# Altium schematic sheet IO.SchDoc(IO)
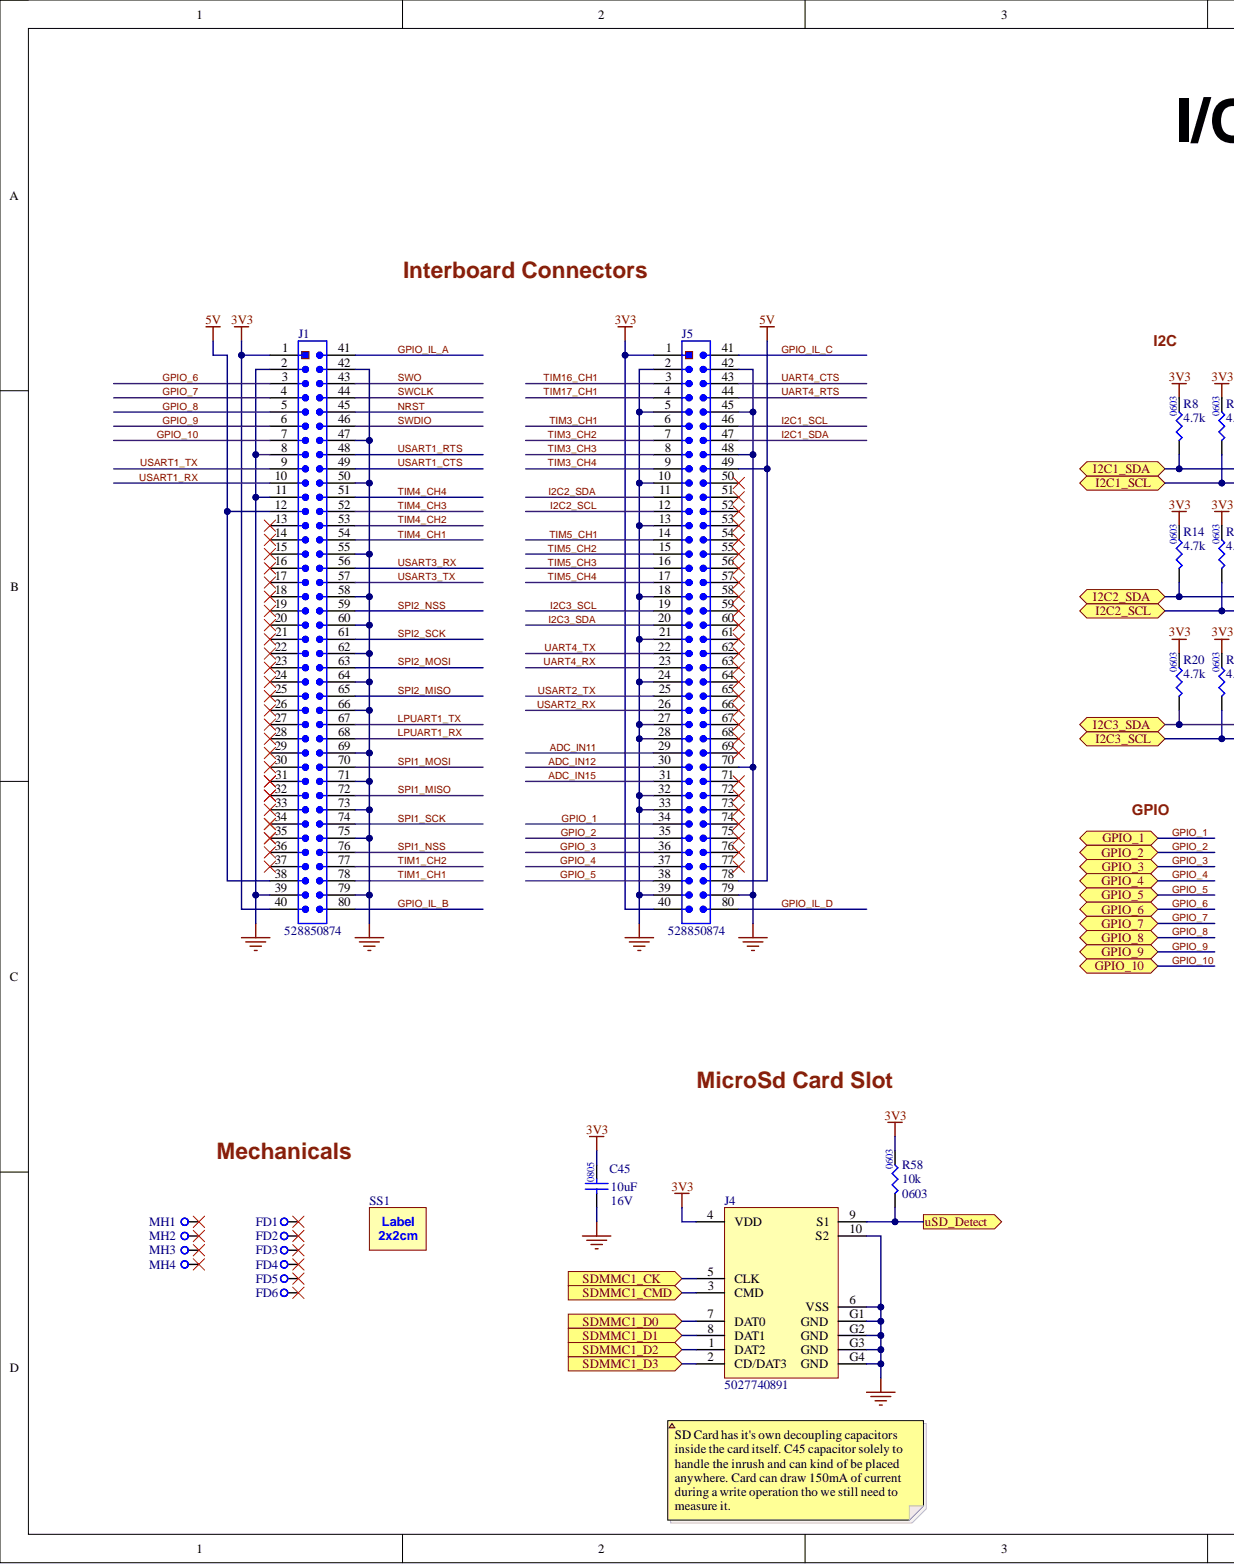
[diagram p0: IO.SchDoc, left]
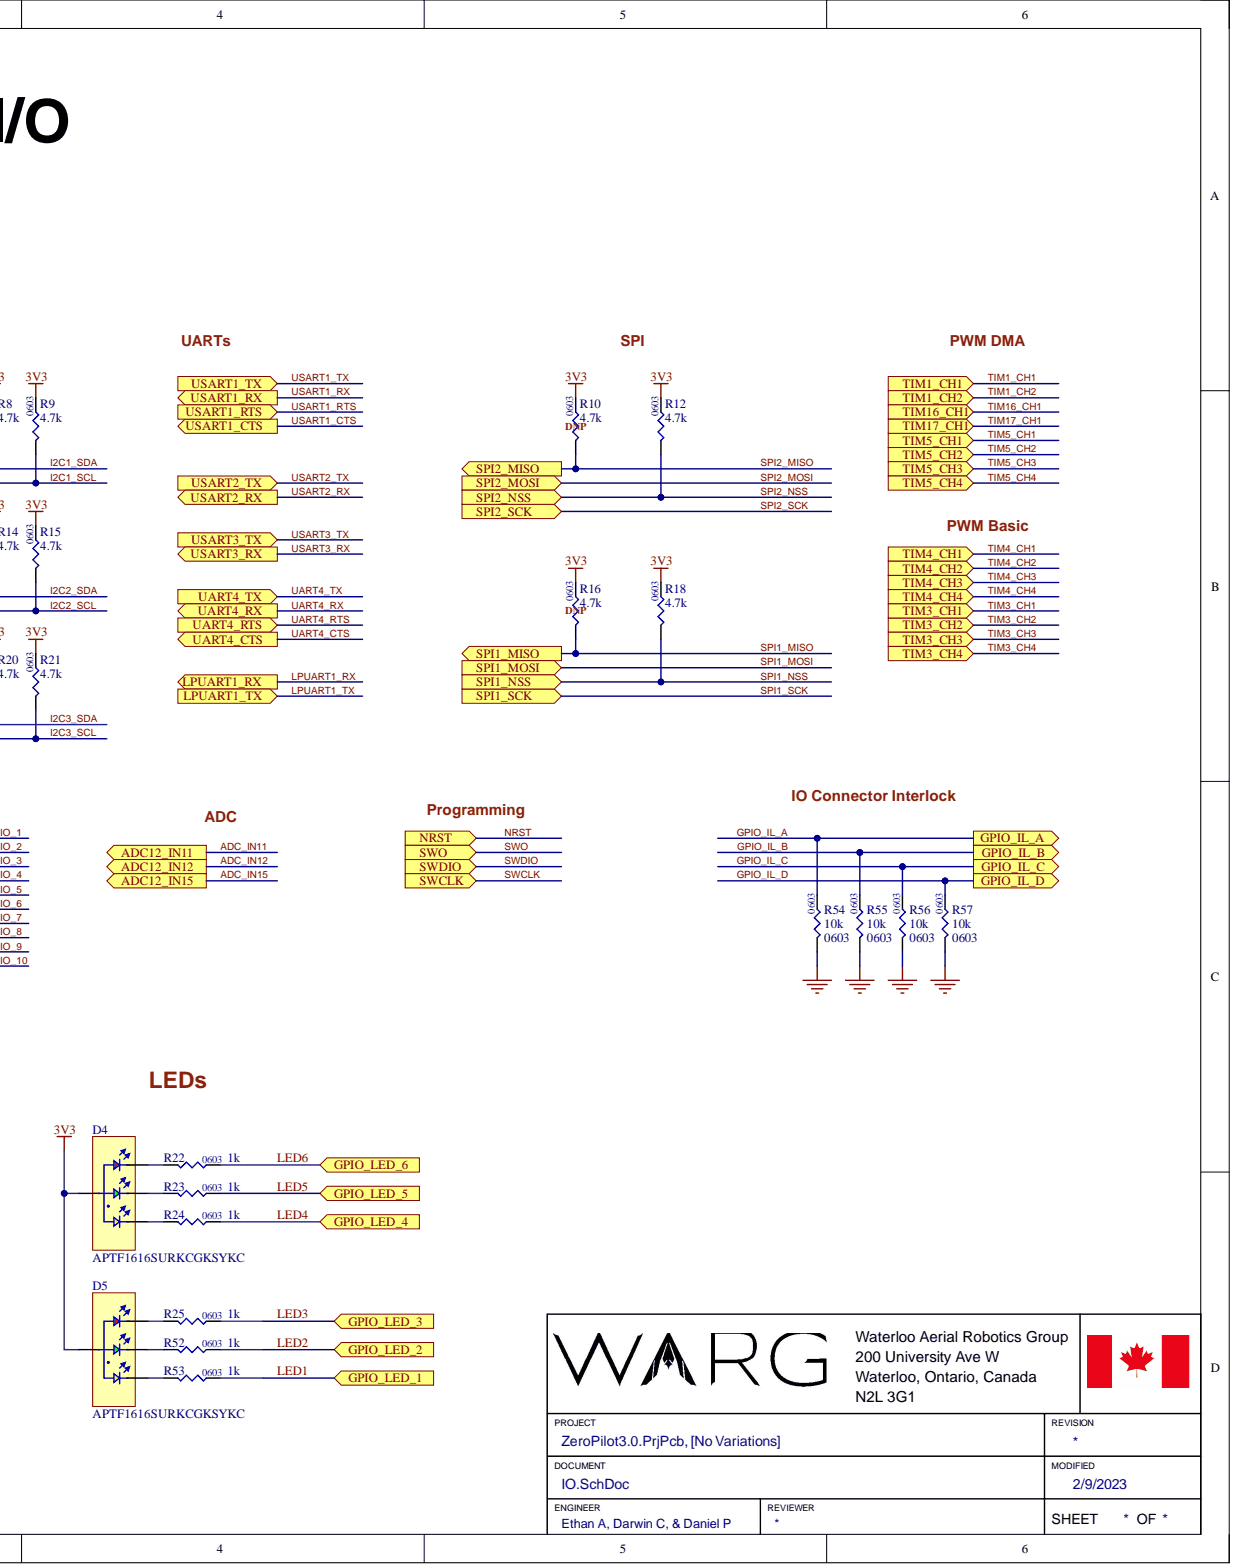
[diagram p1: IO.SchDoc, right]

## Parts
C45  value 10uF  Capacitance: 10uF  Package: 0805  Voltage: 16V  (hidden comment: CL21A106KOQNNNE)
D4  APTF1616SURKCGKSYKC
D5  APTF1616SURKCGKSYKC
FD1  FIDUCIAL-STANDARD  (value not drawn)
FD2  FIDUCIAL-STANDARD  (value not drawn)
FD3  FIDUCIAL-STANDARD  (value not drawn)
FD4  FIDUCIAL-STANDARD  (value not drawn)
FD5  FIDUCIAL-STANDARD  (value not drawn)
FD6  FIDUCIAL-STANDARD  (value not drawn)
J1  528850874
J4  5027740891
J5  528850874
MH1  M3HOLE-STANDARD  (value not drawn)
MH2  M3HOLE-STANDARD  (value not drawn)
MH3  M3HOLE-STANDARD  (value not drawn)
MH4  M3HOLE-STANDARD  (value not drawn)
R8  value 4.7k  Case/Package: 0603  Resistance: 4.7k  (hidden comment: RC0603JR-074K7L)
R9  value 4.7k  Case/Package: 0603  Resistance: 4.7k  (hidden comment: RC0603JR-074K7L)
R10  value 4.7k  Case/Package: 0603  Resistance: 4.7k  (hidden comment: RC0603JR-074K7L)
R12  value 4.7k  Case/Package: 0603  Resistance: 4.7k  (hidden comment: RC0603JR-074K7L)
R14  value 4.7k  Case/Package: 0603  Resistance: 4.7k  (hidden comment: RC0603JR-074K7L)
R15  value 4.7k  Case/Package: 0603  Resistance: 4.7k  (hidden comment: RC0603JR-074K7L)
R16  value 4.7k  Case/Package: 0603  Resistance: 4.7k  (hidden comment: RC0603JR-074K7L)
R18  value 4.7k  Case/Package: 0603  Resistance: 4.7k  (hidden comment: RC0603JR-074K7L)
R20  value 4.7k  Case/Package: 0603  Resistance: 4.7k  (hidden comment: RC0603JR-074K7L)
R21  value 4.7k  Case/Package: 0603  Resistance: 4.7k  (hidden comment: RC0603JR-074K7L)
R22  value 1k  Case/Package: 0603  (hidden comment: RC0603FR-071KL)
R23  value 1k  Case/Package: 0603  (hidden comment: RC0603FR-071KL)
R24  value 1k  Case/Package: 0603  (hidden comment: RC0603FR-071KL)
R25  value 1k  Case/Package: 0603  (hidden comment: RC0603FR-071KL)
R52  value 1k  Case/Package: 0603  (hidden comment: RC0603FR-071KL)
R53  value 1k  Case/Package: 0603  (hidden comment: RC0603FR-071KL)
R54  value 10k  Package: 0603  Resistance: 10k  (hidden comment: RC0603FR-0710KL)
R55  value 10k  Package: 0603  Resistance: 10k  (hidden comment: RC0603FR-0710KL)
R56  value 10k  Package: 0603  Resistance: 10k  (hidden comment: RC0603FR-0710KL)
R57  value 10k  Package: 0603  Resistance: 10k  (hidden comment: RC0603FR-0710KL)
R58  value 10k  Package: 0603  Resistance: 10k  (hidden comment: RC0603FR-0710KL)
SS1  LABEL-STANDARD  (value not drawn)

## Ports
ADC12_IN11, ADC12_IN12, ADC12_IN15, GPIO_1, GPIO_2, GPIO_3, GPIO_4, GPIO_5, GPIO_6, GPIO_7, GPIO_8, GPIO_9, GPIO_10, GPIO_IL_A, GPIO_IL_B, GPIO_IL_C, GPIO_IL_D, GPIO_LED_1, GPIO_LED_2, GPIO_LED_3, GPIO_LED_4, GPIO_LED_5, GPIO_LED_6, I2C1_SCL, I2C1_SDA, I2C2_SCL, I2C2_SDA, I2C3_SCL, I2C3_SDA, LPUART1_RX, LPUART1_TX, NRST, SDMMC1_CK, SDMMC1_CMD, SDMMC1_D0, SDMMC1_D1, SDMMC1_D2, SDMMC1_D3, SPI1_MISO, SPI1_MOSI, SPI1_NSS, SPI1_SCK, SPI2_MISO, SPI2_MOSI, SPI2_NSS, SPI2_SCK, SWCLK, SWDIO, SWO, TIM1_CH1, TIM1_CH2, TIM3_CH1, TIM3_CH2, TIM3_CH3, TIM3_CH4, TIM4_CH1, TIM4_CH2, TIM4_CH3, TIM4_CH4, TIM5_CH1, TIM5_CH2, TIM5_CH3, TIM5_CH4, TIM16_CH1, TIM17_CH1, UART4_CTS, UART4_RTS, UART4_RX, UART4_TX, USART1_CTS, USART1_RTS, USART1_RX, USART1_TX, USART2_RX, USART2_TX, USART3_RX, USART3_TX, uSD_Detect

## Nets
3V3: C45.2 D4.1 D5.1 J1.1 J1.40 J4.4(VDD) J5.1 J5.40 R8.2 R9.2 R10.2 R12.2 R14.2 R15.2 R16.2 R18.2 R20.2 R21.2 R58.2
5V: J1.12 J1.38 J5.49 J5.78
GND: C45.1 J1.2 J1.8 J1.11 J1.39 J1.42 J1.47 J1.50 J1.55 J1.58 J1.60 J1.62 J1.64 J1.66 J1.69 J1.71 J1.73 J1.75 J1.79 J4.6(VSS) J4.10(S2) J4.G1(GND) J4.G2(GND) J4.G3(GND) J4.G4(GND) J5.2 J5.5 J5.10 J5.13 J5.18 J5.21 J5.24 J5.27 J5.28 J5.32 J5.33 J5.39 J5.42 J5.45 J5.48 J5.70 J5.79 R54.1 R55.1 R56.1 R57.1
ADC_IN11: J5.29 ; port ADC12_IN11
ADC_IN12: J5.30 ; port ADC12_IN12
ADC_IN15: J5.31 ; port ADC12_IN15
GPIO_1: J5.34 ; port GPIO_1
GPIO_2: J5.35 ; port GPIO_2
GPIO_3: J5.36 ; port GPIO_3
GPIO_4: J5.37 ; port GPIO_4
GPIO_5: J5.38 ; port GPIO_5
GPIO_6: J1.3 ; port GPIO_6
GPIO_7: J1.4 ; port GPIO_7
GPIO_8: J1.5 ; port GPIO_8
GPIO_9: J1.6 ; port GPIO_9
GPIO_10: J1.7 ; port GPIO_10
GPIO_IL_A: J1.41 R54.2 ; port GPIO_IL_A
GPIO_IL_B: J1.80 R55.2 ; port GPIO_IL_B
GPIO_IL_C: J5.41 R56.2 ; port GPIO_IL_C
GPIO_IL_D: J5.80 R57.2 ; port GPIO_IL_D
I2C1_SCL: J5.46 R9.1 ; port I2C1_SCL
I2C1_SDA: J5.47 R8.1 ; port I2C1_SDA
I2C2_SCL: J5.12 R15.1 ; port I2C2_SCL
I2C2_SDA: J5.11 R14.1 ; port I2C2_SDA
I2C3_SCL: J5.19 R21.1 ; port I2C3_SCL
I2C3_SDA: J5.20 R20.1 ; port I2C3_SDA
LED1: R53.2 ; port GPIO_LED_1
LED2: R52.2 ; port GPIO_LED_2
LED3: R25.2 ; port GPIO_LED_3
LED4: R24.2 ; port GPIO_LED_4
LED5: R23.2 ; port GPIO_LED_5
LED6: R22.2 ; port GPIO_LED_6
LPUART1_RX: J1.68 ; port LPUART1_RX
LPUART1_TX: J1.67 ; port LPUART1_TX
NRST: J1.45 ; port NRST
SDMMC1_CK: J4.5(CLK) ; port SDMMC1_CK
SDMMC1_CMD: J4.3(CMD) ; port SDMMC1_CMD
SDMMC1_D0: J4.7(DAT0) ; port SDMMC1_D0
SDMMC1_D1: J4.8(DAT1) ; port SDMMC1_D1
SDMMC1_D2: J4.1(DAT2) ; port SDMMC1_D2
SDMMC1_D3: J4.2(CD/DAT3) ; port SDMMC1_D3
SPI1_MISO: J1.72 R16.1 ; port SPI1_MISO
SPI1_MOSI: J1.70 ; port SPI1_MOSI
SPI1_NSS: J1.76 R18.1 ; port SPI1_NSS
SPI1_SCK: J1.74 ; port SPI1_SCK
SPI2_MISO: J1.65 R10.1 ; port SPI2_MISO
SPI2_MOSI: J1.63 ; port SPI2_MOSI
SPI2_NSS: J1.59 R12.1 ; port SPI2_NSS
SPI2_SCK: J1.61 ; port SPI2_SCK
SWCLK: J1.44 ; port SWCLK
SWDIO: J1.46 ; port SWDIO
SWO: J1.43 ; port SWO
TIM1_CH1: J1.78 ; port TIM1_CH1
TIM1_CH2: J1.77 ; port TIM1_CH2
TIM3_CH1: J5.6 ; port TIM3_CH1
TIM3_CH2: J5.7 ; port TIM3_CH2
TIM3_CH3: J5.8 ; port TIM3_CH3
TIM3_CH4: J5.9 ; port TIM3_CH4
TIM4_CH1: J1.54 ; port TIM4_CH1
TIM4_CH2: J1.53 ; port TIM4_CH2
TIM4_CH3: J1.52 ; port TIM4_CH3
TIM4_CH4: J1.51 ; port TIM4_CH4
TIM5_CH1: J5.14 ; port TIM5_CH1
TIM5_CH2: J5.15 ; port TIM5_CH2
TIM5_CH3: J5.16 ; port TIM5_CH3
TIM5_CH4: J5.17 ; port TIM5_CH4
TIM16_CH1: J5.3 ; port TIM16_CH1
TIM17_CH1: J5.4 ; port TIM17_CH1
UART4_CTS: J5.43 ; port UART4_CTS
UART4_RTS: J5.44 ; port UART4_RTS
UART4_RX: J5.23 ; port UART4_RX
UART4_TX: J5.22 ; port UART4_TX
USART1_CTS: J1.49 ; port USART1_CTS
USART1_RTS: J1.48 ; port USART1_RTS
USART1_RX: J1.10 ; port USART1_RX
USART1_TX: J1.9 ; port USART1_TX
USART2_RX: J5.26 ; port USART2_RX
USART2_TX: J5.25 ; port USART2_TX
USART3_RX: J1.56 ; port USART3_RX
USART3_TX: J1.57 ; port USART3_TX
uSD_Detect: J4.9(S1) R58.1 ; port uSD_Detect
NetD4_2: D4.2 R22.1
NetD4_3: D4.3 R23.1
NetD4_4: D4.4 R24.1
NetD5_2: D5.2 R25.1
NetD5_3: D5.3 R52.1
NetD5_4: D5.4 R53.1

Not connected: FD1 1; FD2 1; FD3 1; FD4 1; FD5 1; FD6 1; J1 13 14 15 16 17 18 19 20 21 22 23 24 25 26 27 28 29 30 31 32 33 34 35 36 37; J5 50 51 52 53 54 55 56 57 58 59 60 61 62 63 64 65 66 67 68 69 71 72 73 74 75 76 77; MH1 1; MH2 1; MH3 1; MH4 1

## Library data of the parts
C45 = CMP-001-00016-4: CAP CER 10UF 16V X5R 0805; Component Kind: Standard; Temperature Coefficient: X5R; Library Name: WARG
D4 D5 = CMP-004-00003-5: LED GREEN/RED/YELLOW CLEAR 4SMD; Color: Green,Red,Yellow; Forward Voltage: 2V; Component Kind: Standard; Library Name: WARG
FD1-FD6 = CMP-00028-00000-1: Standard Fiducial; Component Kind: Standard; Library Name: WARG
J1 J5 = CMP-00032-8: Receptacle: SlimStack Board-to-Board Connector, 0.635mm Pitch, 0.635 Series, 6.00 to 10.00mm Mated Height, 6.40mm Mated Width, 80 Circuits; Rows: 2; Component Kind: Standard; Gender: Receptacle; Pins: 80; Pitch: 0.635mm; Series: SlimStack; Library Name: WARG
J4 = CMP-002-00000-7: CONN MICRO SD CARD PUSH-PUSH R/A; Manufacturer: Molex; Number of Positions: 10 (8 + 2); Component Kind: Standard; Datasheet: https://www.molex.com/pdm_docs/sd/5027740891_sd.pdf; Manufacturer Part Number 1: 5027740891; Supplier: Digikey; Supplier Part Number: WM24066CT-ND; Library Name: WARG
MH1-MH4 = CMP-00005-1: Standard M3 Mounting hole as defined by mech team, see confluence for reasonning; Hole Diam: 3.400mm; Plating Diam: 6.000mm; Component Kind: Standard; Library Name: WARG
R8-R10 R12 R14-R16 R18 R20 R21 = CMP-009-00019-3: RES 4.7K OHM 5% 1/10W 0603; Power Rating: 100mW; Voltage Rating (DC): 50V; Component Kind: Standard; Resistance Tolerance: 5%; Library Name: WARG
R22-R25 R52 R53 = CMP-009-00012-3: RES 1k OHM 1% 1/10W 0603; Component Kind: Standard; Power: 1/10W; Library Name: WARG
R54-R58 = CMP-009-00006-4: RES 10K OHM 1% 1/10W 0603; Component Kind: Standard; Power: 1/10W; Library Name: WARG
SS1 = CMP-00028-00001-1: Silk screen marking spot for us to place a QR code. 2cmx2cm size.; Component Kind: Standard; Library Name: WARG
